# Altium schematic sheet PAGE2 - POWER.SchDoc
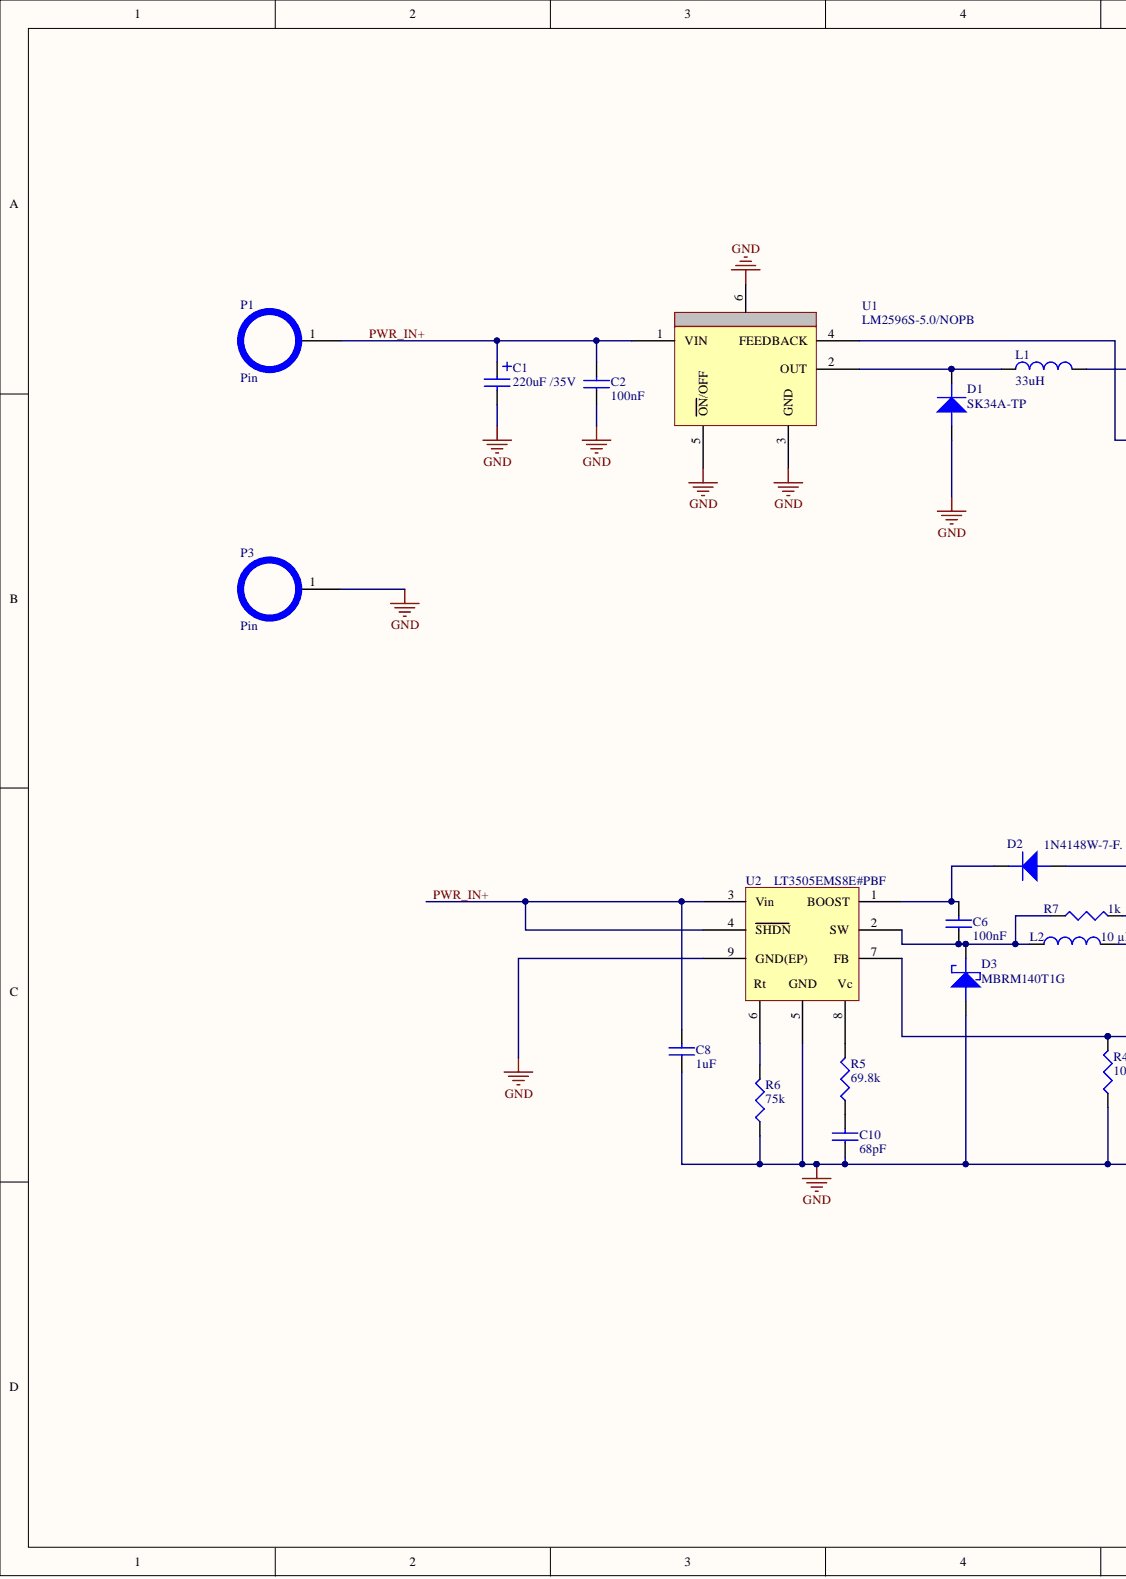
[diagram p0: PAGE2 - POWER.SchDoc, left]
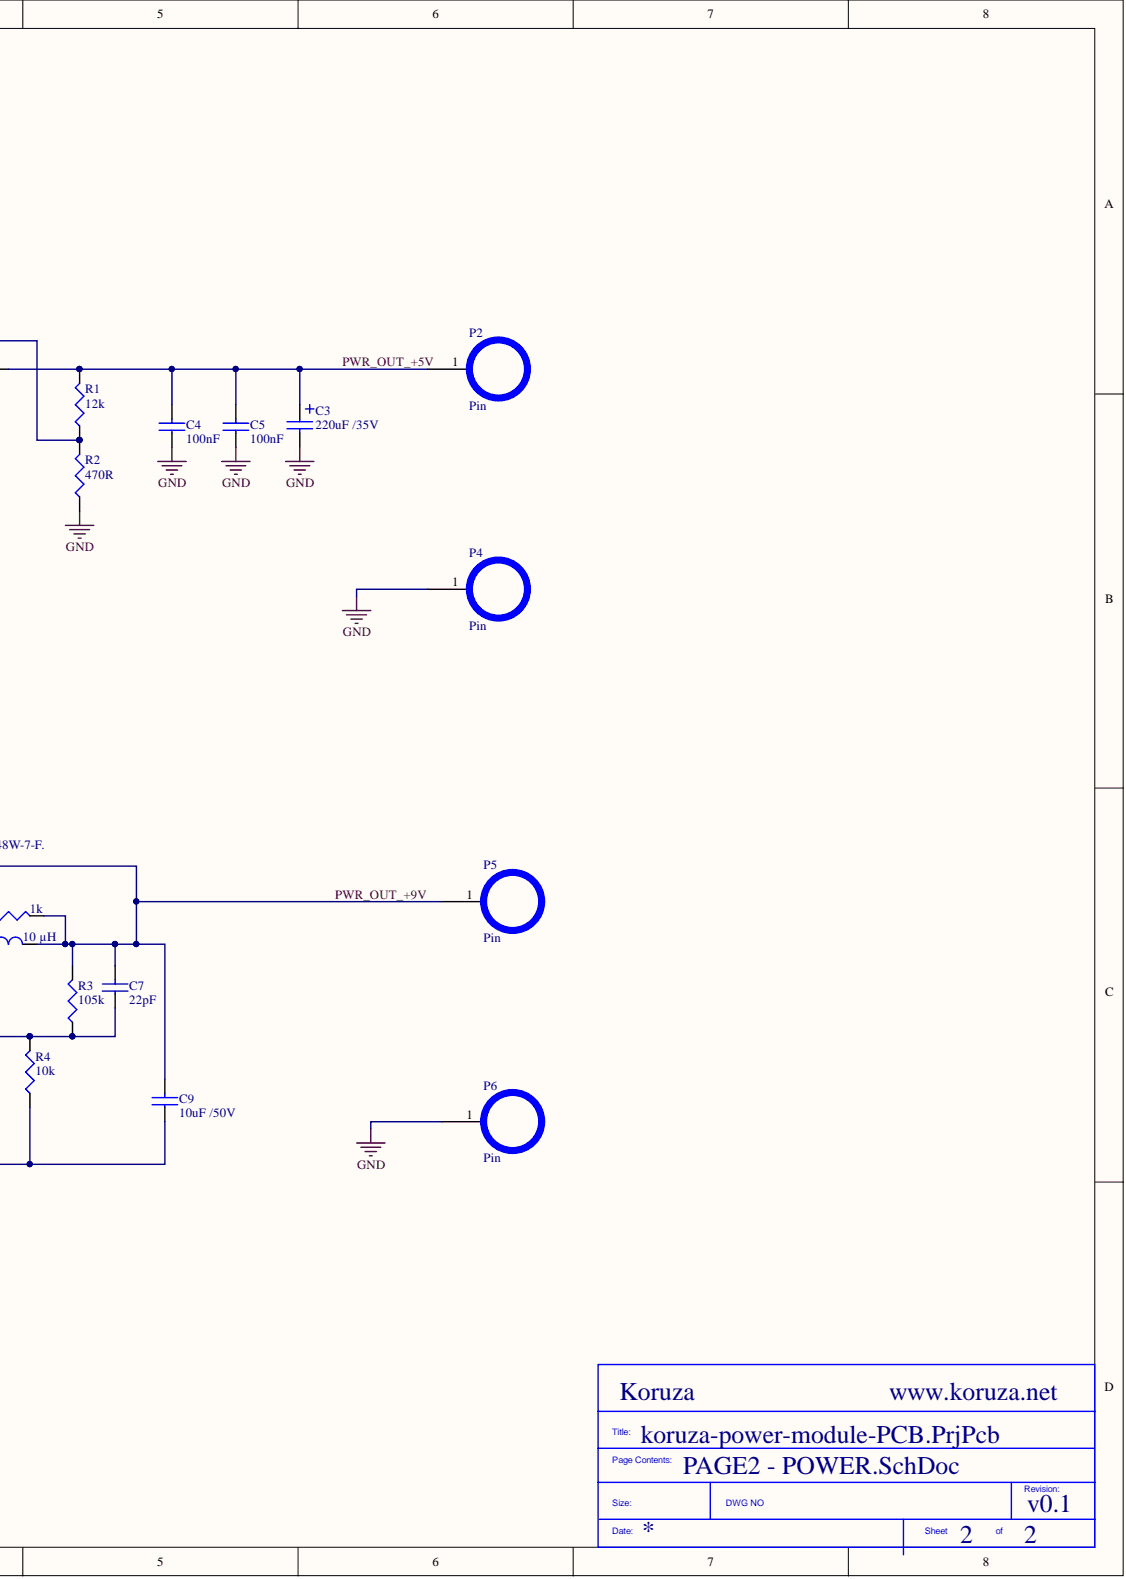
[diagram p1: PAGE2 - POWER.SchDoc, right]

## Parts
C1  220uF /35V  [Cap Can F]
C2  100nF  [CAP0603]
C3  220uF /35V  [Cap Can F]
C4  100nF  [CAP0603]
C5  100nF  [CAP0603]
C6  100nF  [CAP0603]
C7  22pF  [CAP0603]
C8  1uF  [CAP0603]
C9  10uF /50V  [CAP1206]
C10  68pF  [CAP0603]
D1  SK34A-TP  [SMC/DO-214AC]
D2  1N4148W-7-F.  [SOD123]
D3  MBRM140T1G  [POWERMITE]
L1  33uH  [IND DR125 Series]
L2  10 µH  [INDP6545X29N]
P1  Pin  [PCBComponent_1]
P2  Pin  [PCBComponent_1]
P3  Pin  [PCBComponent_1]
P4  Pin  [PCBComponent_1]
P5  Pin  [PCBComponent_1]
P6  Pin  [PCBComponent_1]
R1  12k  [RES0603]
R2  470R  [RES0603]
R3  105k  [RES0603]
R4  10k  [RES0603]
R5  69.8k  [RES0603]
R6  75k  [RES0603]
R7  1k  [RES0603]
U1  LM2596S-5.0/NOPB  [KTT0005B]
U2  LT3505EMS8E#PBF  [MSOP8E]

## Nets
GND: C1.2 C2.1 C3.2 C4.1 C5.1 C8.1 C9.1 C10.1 D1.2 D3.2 P3.1 P4.1 P6.1 R2.1 R4.1 R6.1 U1.3(GND) U1.5(ON/OFF) U1.6 U2.5 U2.9(GND(EP))
PWR_IN+: C1.1 C2.2 C8.2 P1.1 U1.1(VIN) U2.3(Vin) U2.4(SHDN)
PWR_OUT_+5V: C3.1 C4.2 C5.2 L1.2 P2.1 R1.2
PWR_OUT_+9V: C7.2 C9.2 D2.2 L2.2 P5.1 R3.2 R7.2
NetC6_1: C6.1 D3.1 L2.1 R7.1 U2.2(SW)
NetC6_2: C6.2 D2.1 U2.1(BOOST)
NetC7_1: C7.1 R3.1 R4.2 U2.7(FB)
NetC10_2: C10.2 R5.1
NetD1_1: D1.1 L1.1 U1.2(OUT)
NetR1_1: R1.1 R2.2 U1.4(FEEDBACK)
NetR5_2: R5.2 U2.8(Vc)
NetR6_2: R6.2 U2.6(Rt)

## Library data of the parts
C1 C3 = 220uF /35V: PANASONIC ELECTRONIC COMPONENTS - EEEFK1V221P - CAP, ALU ELEC, 220UF, 35V, SMD; Component Kind: Standard; ComponentLink1Description: http://www.farnell.com/datasheets/2002520.pdf?_ga=1.182585071.878552343.1493119789; ComponentLink1URL: http://www.farnell.com/datasheets/2002520.pdf?_ga=1.182585071.878552343.1493119789; ComponentLink2Description: http://www.farnell.com/cad/1703920.zip; ComponentLink2URL: http://www.farnell.com/cad/1703920.zip; ComponentLink3Description: http://www.farnell.com/datasheets/2042572.pdf; ComponentLink3URL: http://www.farnell.com/datasheets/2042572.pdf; Library Name: Capacitors_Schlib.SchLib; Manufacturer: PANASONIC ELECTRONIC COMPONENTS; Manufacturer Part Number: EEEFK1V221P; Pricing 1: 1=0.596, 10=0.364, 50=0.262, 100=0.218, 200=0.187 (GBP); RoHS: YES; Stock 1: 9435; Supplier 1: Farnell; Supplier Part Number 1: 9695877
C2 C4-C6 = 100nF: MURATA - GRM188R71C104KA01J - CAP, MLCC, X7R, 0.1UF, 16V, 0603; Capacitance: 0.1; Capacitance Tolerance: ± 10%; Ceramic Capacitor Case: 0603 [1608 Metric]; Dielectric Characteristic: X7; Product Range: GRM Series; SVHC: No SVHC (20-Jun-2016; Voltage Rating: 16; Automotive Qualification Standard: -; Component Kind: Standard; ComponentLink1Description: http://www.farnell.com/datasheets/2189124.pdf; ComponentLink1URL: http://www.farnell.com/datasheets/2189124.pdf; Library Name: Capacitors.IntLib; Manufacturer: MURATA; Manufacturer Part Number: GRM188R71C104KA01J; MSL: MSL 1 - Unlimited; Operating Temperature Max: 125; Operating Temperature Min: -55; Packaging: Cut Tape; Pricing 1: 10=0.0145, 100=0.0126, 500=0.0081, 2500=0.0059, 5000=0.0052, 10000=0.0046, 50000=0.0036, 100000=0.0035 (GBP); RoHS: YES; Stock 1: 18297; Supplier 1: Farnell; Supplier Part Number 1: 2688519
C7 = 22pF: MURATA - GRM1885C1H220JA01D - CAP, MLCC, C0G/NP0, 22PF, 50V, 0603; Capacitance: 22; Capacitance Tolerance: ± 5%; Capacitor Terminals: SMD<!--FANDB--><div class="features-and-benefits-container"><div class="features-and-benefits-description"><span class="features-benefits-value">The Murata GRM series capacitors are Multilayer Ceramic Chip Capacitors (MLCC) used for general purpose applications. These MLCC capacitors are classified into temperature compensating and high dielectric constant types depending on the change in electrostatic capacitance due to change in temperature. The temperature compensating capacitors feature a small rate of change in electrostatic capacitance as the temperature changes and are used for applications such as filters and high frequency circuit matching. The high dielectric constant capacitors use materials with a high dielectric constant and feature a large electrostatic capacitance. Typical applications are power supply decoupling and smoothing circuits.</span></div><div class="features-and-benefits-list"><ul class="features-and-benefits-list-list"><li class="features-and-benefits-list-item">Large capacity and small size in a multilayer structure</li><li class="features-and-benefits-list-item">Capacitance value ranging from 0.1pF to 220?F</li><li class="features-and-benefits-list-item">Tin plated external electrodes, excellent solderability</li><li class="features-and-benefits-list-item">Provides good high frequency characteristics</li><li class="features-and-benefits-list-item">Excellent pulse response</li><li class="features-and-benefits-list-item">High reliability</li><li class="features-and-benefits-list-item">Capacitor size varies from 0.4×0.2mm to 5.7×5.0mm</li><li class="features-and-benefits-list-item">Rated voltage of 2.5VDC to 3.15; Ceramic Capacitor Case: 0603 [1608 Metric]; Product Range: GRM Series; SVHC: No SVHC (17-Dec-2015); Voltage Rating: 50; Automotive Qualification Standard: -; Capacitance Tolerance ±: ± 5%; Component Kind: Standard; ComponentLink1Description: http://www.farnell.com/datasheets/313472.pdf; ComponentLink1URL: http://www.farnell.com/datasheets/313472.pdf; ComponentLink2Description: http://www.farnell.com/datasheets/2048184.pdf; ComponentLink2URL: http://www.farnell.com/datasheets/2048184.pdf; Dielectric Characteristic: C0G / NP0; Library Name: Capacitors.IntLib; Manufacturer: MURATA; Manufacturer Part Number: GRM1885C1H220JA01D; MSL: -; Operating Temperature Max: 125; Operating Temperature Min: -55; Packaging: Cut Tape; Pricing 1: 10=0.0233, 100=0.0152, 500=0.0112, 1000=0.0088, 2000=0.0086 (GBP); RoHS: YES; Stock 1: 4736; Supplier 1: Farnell; Supplier Part Number 1: 8819831
C8 = 1uF: MURATA - GRM188R61H105KAALD - CAP, MLCC, X5R, 1UF, 50V, 0603; Capacitance: 1; Capacitance Tolerance: ± 10%; Capacitor Terminals: SMD<!--FANDB--><div class="features-and-benefits-container"><div class="features-and-benefits-description"><span class="features-benefits-value">The Murata GRM series capacitors are Multilayer Ceramic Chip Capacitors (MLCC) used for general purpose applications. These MLCC capacitors are classified into temperature compensating and high dielectric constant types depending on the change in electrostatic capacitance due to change in temperature. The temperature compensating capacitors feature a small rate of change in electrostatic capacitance as the temperature changes and are used for applications such as filters and high frequency circuit matching. The high dielectric constant capacitors use materials with a high dielectric constant and feature a large electrostatic capacitance. Typical applications are power supply decoupling and smoothing circuits.</span></div><div class="features-and-benefits-list"><ul class="features-and-benefits-list-list"><li class="features-and-benefits-list-item">Large capacity and small size in a multilayer structure</li><li class="features-and-benefits-list-item">Capacitance value ranging from 0.1pF to 220?F</li><li class="features-and-benefits-list-item">Tin plated external electrodes, excellent solderability</li><li class="features-and-benefits-list-item">Provides good high frequency characteristics</li><li class="features-and-benefits-list-item">Excellent pulse response</li><li class="features-and-benefits-list-item">High reliability</li><li class="features-and-benefits-list-item">Capacitor size varies from 0.4×0.2mm to 5.7×5.0mm</li><li class="features-and-benefits-list-item">Rated voltage of 2.5VDC to 3.15; Ceramic Capacitor Case: 0603 [1608 Metric]; Dielectric Characteristic: X5; Product Range: GRM Series; SVHC: No SVHC (17-Dec-2015); Voltage Rating: 50; Automotive Qualification Standard: -; Capacitance Tolerance ±: ± 10%; Component Kind: Standard; ComponentLink1Description: http://www.farnell.com/datasheets/2048611.pdf; ComponentLink1URL: http://www.farnell.com/datasheets/2048611.pdf; Library Name: Capacitors.IntLib; Manufacturer: MURATA; Manufacturer Part Number: GRM188R61H105KAALD; MSL: -; Operating Temperature Max: 85; Operating Temperature Min: -55; Packaging: Cut Tape; Pricing 1: 10=0.093, 100=0.062, 500=0.0507, 1000=0.0465, 2000=0.0429, 4000=0.0399, 20000=0.0372, 40000=0.0365 (GBP); RoHS: YES; Stock 1: 62682; Supplier 1: Farnell; Supplier Part Number 1: 1845736
C9 = 10uF /50V: MURATA - GRM31CR61H106KA12L - CAP, MLCC, X5R, 10UF, 50V, 1206; Component Kind: Standard; ComponentLink1Description: http://www.farnell.com/datasheets/2048767.pdf; ComponentLink1URL: http://www.farnell.com/datasheets/2048767.pdf; Library Name: Capacitors.IntLib; Manufacturer: MURATA; Manufacturer Part Number: GRM31CR61H106KA12L; Pricing 1: 5=0.368, 50=0.214, 250=0.196, 500=0.178, 1000=0.159 (GBP); RoHS: YES; Stock 1: 51830; Supplier 1: Farnell; Supplier Part Number 1: 1845762
C10 = 68pF: MURATA - GRM1885C1H680JA01D - CAP, MLCC, C0G/NP0, 68PF, 50V, 0603; Capacitance: 68; Capacitance Tolerance: ± 5%; Capacitor Terminals: SMD<!--FANDB--><div class="features-and-benefits-container"><div class="features-and-benefits-description"><span class="features-benefits-value">The Murata GRM series capacitors are Multilayer Ceramic Chip Capacitors (MLCC) used for general purpose applications. These MLCC capacitors are classified into temperature compensating and high dielectric constant types depending on the change in electrostatic capacitance due to change in temperature. The temperature compensating capacitors feature a small rate of change in electrostatic capacitance as the temperature changes and are used for applications such as filters and high frequency circuit matching. The high dielectric constant capacitors use materials with a high dielectric constant and feature a large electrostatic capacitance. Typical applications are power supply decoupling and smoothing circuits.</span></div><div class="features-and-benefits-list"><ul class="features-and-benefits-list-list"><li class="features-and-benefits-list-item">Large capacity and small size in a multilayer structure</li><li class="features-and-benefits-list-item">Capacitance value ranging from 0.1pF to 220?F</li><li class="features-and-benefits-list-item">Tin plated external electrodes, excellent solderability</li><li class="features-and-benefits-list-item">Provides good high frequency characteristics</li><li class="features-and-benefits-list-item">Excellent pulse response</li><li class="features-and-benefits-list-item">High reliability</li><li class="features-and-benefits-list-item">Capacitor size varies from 0.4×0.2mm to 5.7×5.0mm</li><li class="features-and-benefits-list-item">Rated voltage of 2.5VDC to 3.15; Ceramic Capacitor Case: 0603 [1608 Metric]; Product Range: GRM Series; SVHC: No SVHC (17-Dec-2015); Voltage Rating: 50; Automotive Qualification Standard: -; Capacitance Tolerance ±: ± 5%; Component Kind: Standard; ComponentLink1Description: http://www.farnell.com/datasheets/313472.pdf; ComponentLink1URL: http://www.farnell.com/datasheets/313472.pdf; ComponentLink2Description: http://www.farnell.com/datasheets/2048158.pdf; ComponentLink2URL: http://www.farnell.com/datasheets/2048158.pdf; Dielectric Characteristic: C0G / NP0; Library Name: Capacitors.IntLib; Manufacturer: MURATA; Manufacturer Part Number: GRM1885C1H680JA01D; MSL: -; Operating Temperature Max: 125; Operating Temperature Min: -55; Packaging: Cut Tape; Pricing 1: 10=0.0224, 100=0.0149, 500=0.0114, 1000=0.0086, 2000=0.0085 (GBP); RoHS: YES; Stock 1: 9555; Supplier 1: Farnell; Supplier Part Number 1: 8819858
D1 = SK34A-TP: MICRO COMMERCIAL COMPONENTS - SK34A-TP - SCHOTTKY RECTIFIERS, 3A, 40V, DO-214AC; Component Kind: Standard; ComponentLink1Description: http://www.farnell.com/datasheets/1684868.pdf; ComponentLink1URL: http://www.farnell.com/datasheets/1684868.pdf; Library Name: Diodes.IntLib; Manufacturer: MICRO COMMERCIAL COMPONENTS; Manufacturer Part Number: SK34A-TP; Pricing 1: 1=0.736, 10=0.574, 100=0.395, 250=0.328, 500=0.309, 1000=0.287, 5000=0.252, 10000=0.214 (GBP); RoHS: Y-EX; Stock 1: 3770; Supplier 1: Farnell; Supplier Part Number 1: 1924423
D2 = 1N4148W-7-F.: DIODES INC. - 1N4148W-7-F. - DIODE, SWITCH, 300MA, 100V,SOD123; Diode Case Style: SOD-123; Diode Configuration: Single; Diode Type: Switching; Forward Current If(AV): 300; Forward Surge Current Ifsm Max: 2; Forward Voltage VF Max: 1.25; Product Range: 1N4148 Series; Repetitive Reverse Voltage Vrrm Max: 100; Reverse Recovery Time trr Max: 4; SVHC: No SVHC (17-Dec-2015); Automotive Qualification Standard: -; Component Kind: Standard; ComponentLink1Description: http://www.farnell.com/datasheets/2238378.pdf; ComponentLink1URL: http://www.farnell.com/datasheets/2238378.pdf; Library Name: Diodes.IntLib; Manufacturer: DIODES INC.; Manufacturer Part Number: 1N4148W-7-F.; MSL: MSL 1 - Unlimited; No. of Pins: 2; Operating Temperature Max: 150; Operating Temperature Min: -65; Operating Temperature Range: -65°C to +150°C<!--FANDB--><div class="features-and-benefits-container"><div class="features-and-benefits-description"><span class="features-benefits-value">The 1N4148W-7-F is a surface mount fast Switching Diode with moulded plastic case. Suitable for general purpose switching applications.</span></div><div class="features-and-benefits-list"><ul class="features-and-benefits-list-list"><li class="features-and-benefits-list-item">Fast Switching Speed</li><li class="features-and-benefits-list-item">2; Packaging: Cut Tape; Pricing 1: 1=0.136, 25=0.132, 100=0.0465, 500=0.042, 1000=0.024, 3000=0.021, 9000=0.017, 30000=0.0149 (GBP); RoHS: YES; Stock 1: 157320; Supplier 1: Farnell; Supplier Part Number 1: 1776392
D3 = MBRM140T1G: ON SEMICONDUCTOR - MBRM140T1G - DIODE, SCHOTTKY, 1A, POWERMITE; Component Kind: Standard; ComponentLink1Description: http://www.onsemi.com/PowerSolutions/supportDoc.do?type=models&rpn=MBRM140; ComponentLink1URL: http://www.onsemi.com/PowerSolutions/supportDoc.do?type=models&rpn=MBRM140; ComponentLink2Description: http://www.farnell.com/datasheets/1708273.pdf; ComponentLink2URL: http://www.farnell.com/datasheets/1708273.pdf; ComponentLink3Description: http://www.farnell.com/datasheets/2036933.pdf; ComponentLink3URL: http://www.farnell.com/datasheets/2036933.pdf; Library Name: Diodes.IntLib; Manufacturer: ON SEMICONDUCTOR; Manufacturer Part Number: MBRM140T1G; Pricing 1: 5=0.259, 50=0.134, 250=0.123, 500=0.092, 1500=0.0881 (GBP); RoHS: YES; Stock 1: 7058; Supplier 1: Farnell; Supplier Part Number 1: 1459067
L1 = 33uH: COILTRONICS - DR125-330-R - INDUCTOR, SMD, 33UH, 3.28A; Inductance: 33; Inductance Tolerance: ± 20%; Inductor Construction: Shielded; No. of Pins: 2Pins<!--FANDB--><div class="features-and-benefits-container"><div class="features-and-benefits-description"><span class="features-benefits-value">The DR125-330-R is a 33; Power Inductor Case: 12.5mm x 12.5mm x 6; Product Range: DR Series; RMS Current (Irms): 3.28; Saturation Current (Isat): 3.84; SVHC: No SVHC (15-Jun-2015); Component Kind: Standard; ComponentLink1Description: http://www.farnell.com/datasheets/87246.pdf; ComponentLink1URL: http://www.farnell.com/datasheets/87246.pdf; DC Resistance Max: -; Library Name: Inductors_Schlib.SchLib; Manufacturer: COILTRONICS; Manufacturer Part Number: DR125-330-R; Packaging: Cut Tape; Pricing 1: 1=1.53, 10=1.02, 50=0.765, 100=0.68, 200=0.612, 600=0.51, 3000=0.456, 6000=0.447 (GBP); RoHS: YES; Stock 1: 5001; Supplier 1: Farnell; Supplier Part Number 1: 2075603
L2 = SDR0703-100KL: BOURNS - SDR0703-100KL - INDUCTOR, POWER, 10UH, 10%, 1A; DC Resistance Max: 0.17; Inductance: 10; Inductor Construction: Unshielded; No. of Pins: 2; Power Inductor Case: 6.5mm x 4.5mm x 2.7; Product Range: SDR0703 Series; Q Factor: 23; Q Test Frequency: 2.52; RMS Current (Irms): 1; Saturation Current (Isat): 1.4; Self Resonant Frequency Min: 36MHz<!--FANDB--><div class="features-and-benefits-container"><div class="features-and-benefits-description"><span class="features-benefits-value">The SDR0703 series SMD Power Inductor with ferrite core and tin/silver termination. It has liquid-crystal polymer and 6020H-6 adhesive and an enamelled copper wire winding. It is suitable for input/output of DC/DC converters.</span></div><div class="features-and-benefits-list"><ul class="features-and-benefits-list-list"><li class="features-and-benefits-list-item">Available in E12 series</li><li class="features-and-benefits-list-item">Low height of only 2.7; SVHC: No SVHC (20-Jun-2016); Component Kind: Standard; ComponentLink1Description: http://www.bourns.com/engineering/SDR0703/sdr0703.stp; ComponentLink1URL: http://www.bourns.com/engineering/SDR0703/sdr0703.stp; ComponentLink2Description: http://www.farnell.com/datasheets/707207.pdf; ComponentLink2URL: http://www.farnell.com/datasheets/707207.pdf; Inductance Tolerance: ± 10%; Lead Spacing: 4; Library Name: Inductors.IntLib; Manufacturer: BOURNS; Manufacturer Part Number: SDR0703-100KL; Packaging: Cut Tape; Pricing 1: 1=0.594, 10=0.44, 50=0.338, 100=0.303, 200=0.274, 500=0.251, 2500=0.214, 5000=0.194 (GBP); RoHS: YES; Stock 1: 176; Supplier 1: Farnell; Supplier Part Number 1: 1929709
P1-P6 = Pin: Pin for board to board connection; Component Kind: Standard; Library Name: koruza-power-module-Schlib.SchLib
R1 = 12k: MULTICOMP - MC0063W0603112K - RES, THICK FILM, 12K, 1%, 0.063W, 0603; Power Rating: 63; Product Range: MC Series; Reel Quantity: 5000; Resistance: 12; Resistor Case Style: 0603 [1608 Metric]; Resistor Mounting: Surface Mount Device<!--FANDB--><div class="features-and-benefits-container"><div class="features-and-benefits-description"><span class="features-benefits-value">The Multicomp MC series current sense resistors are thick film chip resistors. The resistance range includes E96 and E24 decade values from 1ohm to 10Mohm.</span></div><div class="features-and-benefits-list"><ul class="features-and-benefits-list-list"><li class="features-and-benefits-list-item">EIA 0402, 0603, 0805, 1206 chip sizes available</li><li class="features-and-benefits-list-item">Small size and low weight</li><li class="features-and-benefits-list-item">Suitable for both wave and reflow soldering</li><li class="features-and-benefits-list-item">Reduction of assembly costs</li><li class="features-and-benefits-list-item">Maximum working voltage of 50V(0402, 0603), 150V(0805), 200V(1206)</li><li class="features-and-benefits-list-item">Available in ±1%, ±2% and ±5% tolerance</li><li class="features-and-benefits-list-item">Power rating of 62.5mW, 100mW and 125mW</li><li class="features-and-benefits-list-item">±400ppm/°C(1ohm to 10ohm), ±200ppm/°C(11ohm to 100ohm), ±100; Temperature Coefficient: ± 100; Voltage Rating: 50; Automotive Qualification Standard: -; Component Kind: Standard; ComponentLink1Description: http://www.farnell.com/datasheets/1788326.pdf; ComponentLink1URL: http://www.farnell.com/datasheets/1788326.pdf; ComponentLink2Description: http://www.farnell.com/datasheets/1944283.pdf; ComponentLink2URL: http://www.farnell.com/datasheets/1944283.pdf; Library Name: Resistors.IntLib; Manufacturer: MULTICOMP; Manufacturer Part Number: MC0063W0603112K; Packaging: Tape & Reel; Pricing 1: 5000=0.0006, 25000=0.0005, 50000=0.0004 (GBP); Resistance Tolerance: ± 1%; Resistor Element Material: Thick Film; RoHS: Y-EX; Stock 1: 784996; Supplier 1: Farnell; Supplier Part Number 1: 2130943
R2 = 470R: MULTICOMP - MCMR06X471 JTL - RES, CERAMIC, 470R, 5%, 0.1W, 0603; Component Kind: Standard; ComponentLink1Description: http://www.farnell.com/datasheets/1716707.pdf; ComponentLink1URL: http://www.farnell.com/datasheets/1716707.pdf; Library Name: Resistors.IntLib; Manufacturer: MULTICOMP; Manufacturer Part Number: MCMR06X471 JTL; Pricing 1: 10=0.0089, 100=0.0076, 500=0.0063, 1000=0.0049, 2500=0.0033, 5000=0.0024, 25000=0.0016, 50000=0.0013 (GBP); RoHS: Y-EX; Stock 1: 3786; Supplier 1: Farnell; Supplier Part Number 1: 2073513
R3 = 105k: MULTICOMP - MC0063W06031105K - RES, THICK FILM, 105K, 1%, 0.063W, 0603; External Depth: 0.45; External Length / Height: 1.6; External Width: 0.8; No. of Pins: 2; Power Rating: 63; Product Range: MC Series; Reel Quantity: 5000; Resistance: 105; Resistor Case Style: 0603 [1608 Metric]; Resistor Mounting: Surface Mount Device; SVHC: No SVHC (12-Jan-2017); Temperature Coeff. +: 100; Temperature Coefficient: ± 100; Voltage Rating: 50; Voltage Rating V DC: 50V<!--FANDB--><div class="features-and-benefits-container"><div class="features-and-benefits-description"><span class="features-benefits-value">The Multicomp MC series current sense resistors are thick film chip resistors. The resistance range includes E96 and E24 decade values from 1ohm to 10Mohm.</span></div><div class="features-and-benefits-list"><ul class="features-and-benefits-list-list"><li class="features-and-benefits-list-item">EIA 0402, 0603, 0805, 1206 chip sizes available</li><li class="features-and-benefits-list-item">Small size and low weight</li><li class="features-and-benefits-list-item">Suitable for both wave and reflow soldering</li><li class="features-and-benefits-list-item">Reduction of assembly costs</li><li class="features-and-benefits-list-item">Maximum working voltage of 50V(0402, 0603), 150V(0805), 200V(1206)</li><li class="features-and-benefits-list-item">Available in ±1%, ±2% and ±5% tolerance</li><li class="features-and-benefits-list-item">Power rating of 62.5mW, 100mW and 125mW</li><li class="features-and-benefits-list-item">±400ppm/°C(1ohm to 10ohm), ±200ppm/°C(11ohm to 100ohm), ±100; Automotive Qualification Standard: -; Component Kind: Standard; ComponentLink1Description: http://www.farnell.com/datasheets/1788326.pdf; ComponentLink1URL: http://www.farnell.com/datasheets/1788326.pdf; Library Name: Resistors.IntLib; Manufacturer: MULTICOMP; Manufacturer Part Number: MC0063W06031105K; Operating Temperature Max: 155; Operating Temperature Min: -55; Operating Temperature Range: -55°C to +155; Packaging: Cut Tape; Pricing 1: 10=0.0011, 100=0.001, 500=0.0009, 1000=0.0008, 2500=0.0007 (GBP); Resistance Tolerance: ± 1%; Resistor Element Material: Thick Film; RoHS: Y-EX; Stock 1: 8970; Supplier 1: Farnell; Supplier Part Number 1: 1170988; Tape Width: 8; Temperature Coeff. -: 100; Tolerance +: 1%; Tolerance -: 1%
R4 = 10k: MULTICOMP - MCMR06X103 JTL - RES, CERAMIC, 10K, 5%, 0.1W, 0603; Automotive Qualification Standard: -<!--FANDB--><div class="features-and-benefits-container"><div class="features-and-benefits-description"><span class="features-benefits-value">The Multicomp MCMR series resistors are automotive grade, anti sulphured thick film chip resistor. The resistance range includes E24 and E96 decade values from 1ohm to 10Mohm. These resistors are constructed on top of a high grade ceramic body.</span></div><div class="features-and-benefits-list"><ul class="features-and-benefits-list-list"><li class="features-and-benefits-list-item">EIA 0402, 0603, 0805, 1206 chip sizes available</li><li class="features-and-benefits-list-item">High reliability and stability</li><li class="features-and-benefits-list-item">Automotive grade AEC-Q200 compliant</li><li class="features-and-benefits-list-item">Complaint with (IEC 60068-1) 55/155/56 climatic category</li><li class="features-and-benefits-list-item">Maximum operation voltage of 50V, 75V, 150V and 200V</li><li class="features-and-benefits-list-item">Power rating of 62.5mW, 100mW, 125mW and 250mW</li><li class="features-and-benefits-list-item">Available in ±1% and ±5% tolerance</li><li class="features-and-benefits-list-item">Temperature coefficient (TCR) of +200ppm/°C, +100ppm/°C and -200ppm/°C to +400ppm/°C</li><li class="features-and-benefits-list-item">Operating temperature range -55°C to 155; Power Rating: 100; Product Range: MCMR Series; Resistance: 10; Resistor Case Style: 0603 [1608 Metric]; Temperature Coefficient: ± 100; Voltage Rating: 75; Component Kind: Standard; ComponentLink1Description: http://www.farnell.com/datasheets/1716707.pdf; ComponentLink1URL: http://www.farnell.com/datasheets/1716707.pdf; Library Name: Resistors.IntLib; Manufacturer: MULTICOMP; Manufacturer Part Number: MCMR06X103 JTL; Packaging: Cut Tape; Pricing 1: 10=0.0087, 100=0.0074, 500=0.0061, 1000=0.0048, 2500=0.0032, 5000=0.0024, 25000=0.0016, 50000=0.0013 (GBP); Resistance Tolerance: ± 5%; Resistor Element Material: Ceramic; RoHS: Y-EX; Stock 1: 19791; Supplier 1: Farnell; Supplier Part Number 1: 2073356
R5 = 69.8k: MULTICOMP - MC0063W0603169K8 - RES, THICK FILM, 69K8, 1%, 0.063W, 0603; External Depth: 0.45; External Length / Height: 1.6; External Width: 0.8; No. of Pins: 2; Power Rating: 63; Product Range: MC Series; Reel Quantity: 5000; Resistance: 69.8; Resistor Case Style: 0603 [1608 Metric]; Resistor Mounting: Surface Mount Device; SVHC: No SVHC (12-Jan-2017); Temperature Coeff. +: 100; Temperature Coefficient: ± 100; Voltage Rating: 50; Automotive Qualification Standard: -; Component Kind: Standard; ComponentLink1Description: http://www.farnell.com/datasheets/1788326.pdf; ComponentLink1URL: http://www.farnell.com/datasheets/1788326.pdf; Library Name: Resistors.IntLib; Manufacturer: MULTICOMP; Manufacturer Part Number: MC0063W0603169K8; Operating Temperature Max: 155; Operating Temperature Min: -55; Operating Temperature Range: -55°C to +155; Packaging: Cut Tape; Pricing 1: 10=0.0115, 100=0.0089, 500=0.0063, 1000=0.0051, 2500=0.0042, 5000=0.0032, 25000=0.0021, 50000=0.0017 (GBP); Resistance Tolerance: ± 1%; Resistor Element Material: Thick Film; RoHS: Y-EX; Stock 1: 4756; Supplier 1: Farnell; Supplier Part Number 1: 1170972; Tape Width: 8; Temperature Coeff. -: 100; Tolerance +: 1%; Tolerance -: 1%; Voltage Rating V DC: 50
R6 = 75k: MULTICOMP - MCSR06X7502FTL - RES, CERAMIC, 75K, 1%, 0.1W, 0603; Component Kind: Standard; ComponentLink1Description: http://www.farnell.com/datasheets/1716711.pdf; ComponentLink1URL: http://www.farnell.com/datasheets/1716711.pdf; Library Name: Resistors.IntLib; Manufacturer: MULTICOMP; Manufacturer Part Number: MCSR06X7502FTL; Pricing 1: 10=0.0145, 100=0.0113, 500=0.008, 1000=0.0064, 2500=0.004, 5000=0.0031, 25000=0.0021, 50000=0.002 (GBP); RoHS: Y-EX; Stock 1: 1882; Supplier 1: Farnell; Supplier Part Number 1: 2074304
R7 = 1k: MULTICOMP - MCMR06X102 JTL - RES, CERAMIC, 1K, 5%, 0.1W, 0603; Power Rating: 100; Product Range: MCMR Series; Resistance: 1; Resistor Case Style: 0603 [1608 Metric]; Resistor Mounting: Surface Mount Device<!--FANDB--><div class="features-and-benefits-container"><div class="features-and-benefits-description"><span class="features-benefits-value">The Multicomp MCMR series resistors are automotive grade, anti sulphured thick film chip resistor. The resistance range includes E24 and E96 decade values from 1ohm to 10Mohm. These resistors are constructed on top of a high grade ceramic body.</span></div><div class="features-and-benefits-list"><ul class="features-and-benefits-list-list"><li class="features-and-benefits-list-item">EIA 0402, 0603, 0805, 1206 chip sizes available</li><li class="features-and-benefits-list-item">High reliability and stability</li><li class="features-and-benefits-list-item">Automotive grade AEC-Q200 compliant</li><li class="features-and-benefits-list-item">Complaint with (IEC 60068-1) 55/155/56 climatic category</li><li class="features-and-benefits-list-item">Maximum operation voltage of 50V, 75V, 150V and 200V</li><li class="features-and-benefits-list-item">Power rating of 62.5mW, 100mW, 125mW and 250mW</li><li class="features-and-benefits-list-item">Available in ±1% and ±5% tolerance</li><li class="features-and-benefits-list-item">Temperature coefficient (TCR) of +200ppm/°C, +100ppm/°C and -200ppm/°C to +400ppm/°C</li><li class="features-and-benefits-list-item">Operating temperature range -55°C to 155; Temperature Coefficient: ± 100; Voltage Rating: 75; Automotive Qualification Standard: -; Component Kind: Standard; ComponentLink1Description: http://www.farnell.com/datasheets/1716707.pdf; ComponentLink1URL: http://www.farnell.com/datasheets/1716707.pdf; Library Name: Resistors.IntLib; Manufacturer: MULTICOMP; Manufacturer Part Number: MCMR06X102 JTL; Packaging: Cut Tape; Pricing 1: 10=0.0089, 100=0.0076, 500=0.0063, 1000=0.0049, 2500=0.0033, 5000=0.0024, 25000=0.0016, 50000=0.0013 (GBP); Resistance Tolerance: ± 5%; Resistor Element Material: Ceramic; RoHS: Y-EX; Stock 1: 18133; Supplier 1: Farnell; Supplier Part Number 1: 2073354
U1 = LM2596S-5.0/NOPB: TEXAS INSTRUMENTS - LM2596S-5.0/NOPB - IC, DC/DC CONVERTER, 3A; Accuracy %: 4%; DC / DC Converter IC Case: TO-263; Frequency: 150; Input Voltage Max: 40; Input Voltage Min: 4.5; No. of Outputs: 1; No. of Pins: 5; Output Current: 3; Primary Input Voltage: 12; SVHC: No SVHC (15-Jun-2015); Topology: Buck (Step Down); Voltage Regulator Type: Step Down (Buck)<!--FANDB--><div class="features-and-benefits-container"><div class="features-and-benefits-description"><span class="features-benefits-value">The LM2596S-5.0/NOPB is a step-down Voltage Regulator, provides all the active functions for a step-down (buck) switching regulator, capable of driving a 3A load with excellent line and load regulation. This device is available in fixed output voltages of 3.3, 5, 12V and an adjustable output version. Requiring a minimum number of external components, these regulators are simple to use and include internal frequency compensation and a fixed-frequency oscillator. The LM2596S-5.0/NOPB series operates at a switching frequency of 150kHz thus allowing smaller sized filter components than what would be needed with lower frequency switching regulators.</span></div><div class="features-and-benefits-list"><ul class="features-and-benefits-list-list"><li class="features-and-benefits-list-item">Ensured 3A Output Load Current</li><li class="features-and-benefits-list-item">Input Voltage Range up to 40V</li><li class="features-and-benefits-list-item">Requires Only 4 External Components</li><li class="features-and-benefits-list-item">Excellent Line and Load Regulation Specifications</li><li class="features-and-benefits-list-item">150kHz Fixed Frequency Internal Oscillator</li><li class="features-and-benefits-list-item">TTL Shutdown Capability</li><li class="features-and-benefits-list-item">Low-power Standby Mode, IQ Typically 80; Automotive Qualification Standard: -; Component Kind: Standard; ComponentLink1Description: http://www.ti.com/general/docs/lit/getliterature.tsp?genericPartNumber=LM2596&amp;&amp;fileType=pdf; ComponentLink1URL: http://www.ti.com/general/docs/lit/getliterature.tsp?genericPartNumber=LM2596&amp;&amp;fileType=pdf; Library Name: TI Power Management Switch Regulator Schlib.SchLib; Manufacturer: TEXAS INSTRUMENTS; Manufacturer Part Number: LM2596S-5.0/NOPB; MSL: MSL 3 - 168 hours; Operating Temperature Max: 125; Operating Temperature Min: -40; Operating Temperature Range: -40°C to +125; Output Voltage: 5; Output Voltage Nom.: 5; Packaging: Cut Tape; Pricing 1: 1=4.12, 10=3.34, 25=3.12, 50=2.95, 100=2.74, 250=2.6, 500=2.2 (GBP); Product Range: -; RoHS: YES; Stock 1: 52; Supplier 1: Farnell; Supplier Part Number 1: 1469195; Supply Voltage Max: 40; Supply Voltage Min: 4.5; Switching Frequency: 150; Termination Type: Surface Mount Device
U2 = LT3505EMS8E#PBF: LINEAR TECHNOLOGY - LT3505EMS8E#PBF - IC, SW REG, 1.2A, STEP D, 8MSOP; Adjustable Output Voltage Min: 780; Base Number: 3505; DC / DC Converter IC Case: MSOP; Frequency: 3; Input Voltage Max: 36; Input Voltage Min: 3.6; No. of Outputs: 1; No. of Pins: 8; Output Current: 1.2; Output Voltage Max: 31.32; SVHC: No SVHC (17-Dec-2015); Topology: Buck (Step Down); Voltage Regulator Type: Step Down (Buck)<!--FANDB--><div class="features-and-benefits-container"><div class="features-and-benefits-description"><span class="features-benefits-value">The LT3505EMS8E#PBF is a current-mode PWM step-down DC-to-DC Converter with an internal 1.4A power switch. The wide operating input range of 3.6 to 36V (40V maximum) makes the LT3505 ideal for regulating power from a wide variety of sources, including unregulated wall transformers and 24; Automotive Qualification Standard: -; Component Kind: Standard; ComponentLink1Description: http://www.farnell.com/simulation/1753236.zip; ComponentLink1URL: http://www.farnell.com/simulation/1753236.zip; ComponentLink2Description: http://www.farnell.com/datasheets/1898069.pdf; ComponentLink2URL: http://www.farnell.com/datasheets/1898069.pdf; Library Name: Linear Power Switch Regulator.IntLib; Manufacturer: LINEAR TECHNOLOGY; Manufacturer Part Number: LT3505EMS8E#PBF; MSL: MSL 1 - Unlimited; Operating Temperature Max: 85; Operating Temperature Min: -40; Operating Temperature Range: -40°C to +85; Output Voltage Min: 780; Packaging: Cut Tape; Pricing 1: 1=4.65, 25=2.98, 100=2.49 (GBP); Primary Input Voltage: 36; Product Range: -; RoHS: YES; Supplier 1: Farnell; Supplier Part Number 1: 1663569; Supply Voltage Min: 3.6; Switching Frequency: 3; Switch Output Current Isw Max: 1; Termination Type: Surface Mount Device
